# Altium schematic sheet PTPIC hat.SchDoc
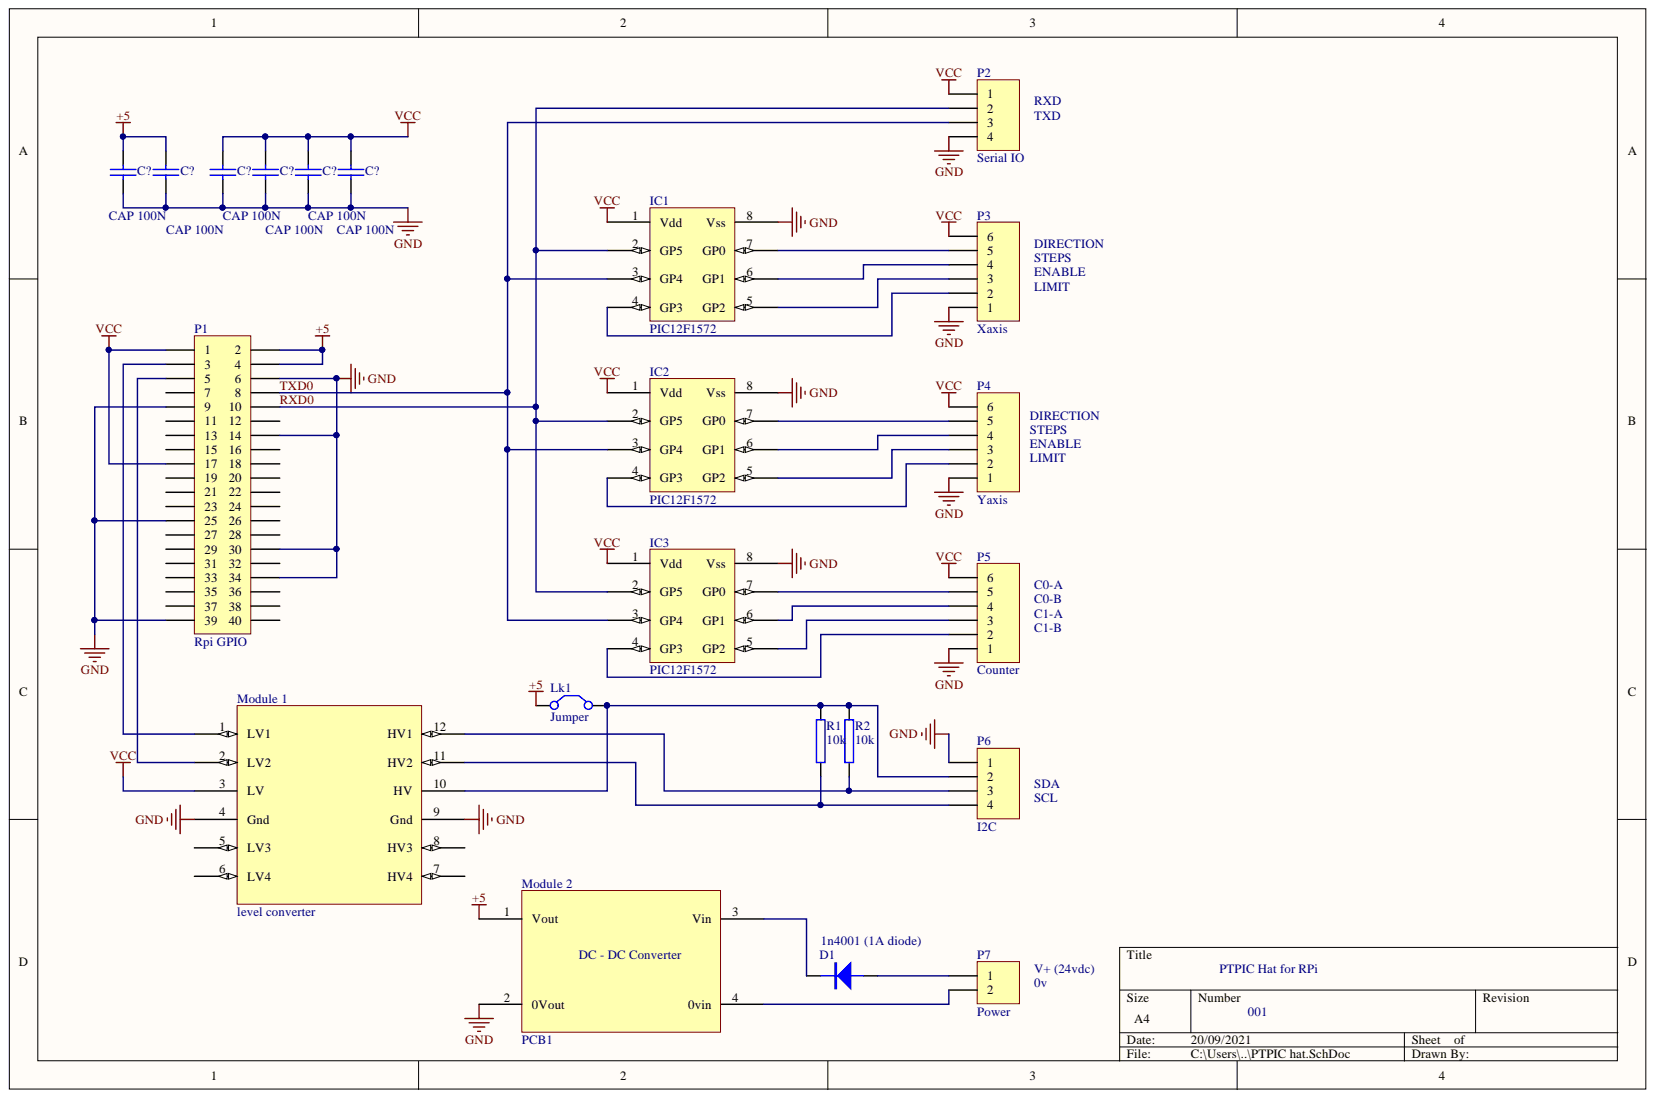
[diagram p0: PTPIC hat.SchDoc]

## Parts
C?  CAP 100N  [0805]
C?  CAP 100N  [0805]
C?  CAP 100N  [0805]
C?  CAP 100N  [0805]
C?  CAP 100N  [0805]
C?  CAP 100N  [0805]
D1  1n4001 (1A diode)  [DIODE0.4]
IC1  PIC12F1572  [DIP8]
IC2  PIC12F1572  [DIP8]
IC3  PIC12F1572  [DIP8]
Lk1  Jumper  [RAD-0.2]
Module_1  level converter
Module_2  PCB1
P1  Rpi GPIO  [HDR2X20]
P2  Serial IO  [HDR1X4]
P3  Xaxis  [HDR1X6]
P4  Yaxis  [HDR1X6]
P5  Counter  [HDR1X6]
P6  I2C  [HDR1X4]
P7  Power  [HDR1X2]
R1  10k  [AXIAL0.4]
R2  10k  [AXIAL0.4]

## Nets
+5: C?.1 Lk1.1 Module_2.1(Vout) P1.2 P1.4
GND: C?.2 IC1.8(Vss) IC2.8(Vss) IC3.8(Vss) Module_1.4(Gnd) Module_1.9(Gnd) Module_2.2(0Vout) P1.6 P1.9 P1.14 P1.25 P1.30 P1.34 P1.39 P2.4 P3.1 P4.1 P5.1 P6.1
VCC: C?.1 IC1.1(Vdd) IC2.1(Vdd) IC3.1(Vdd) Module_1.3(LV) P1.1 P1.17 P2.1 P3.6 P4.6 P5.6
RXD0: IC1.2(GP5) IC2.2(GP5) IC3.2(GP5) P1.10 P2.2
TXD0: IC1.3(GP4) IC2.3(GP4) IC3.3(GP4) P1.8 P2.3
NetD1_A: D1.A P7.1
NetD1_K: D1.K Module_2.3(Vin)
NetIC1_4: IC1.4(GP3) P3.2
NetIC1_5: IC1.5(GP2) P3.3
NetIC1_6: IC1.6(GP1) P3.4
NetIC1_7: IC1.7(GP0) P3.5
NetIC2_4: IC2.4(GP3) P4.2
NetIC2_5: IC2.5(GP2) P4.3
NetIC2_6: IC2.6(GP1) P4.4
NetIC2_7: IC2.7(GP0) P4.5
NetIC3_4: IC3.4(GP3) P5.2
NetIC3_5: IC3.5(GP2) P5.3
NetIC3_6: IC3.6(GP1) P5.4
NetIC3_7: IC3.7(GP0) P5.5
NetLk1_2: Lk1.2 Module_1.10(HV) P6.2 R1.2 R2.2
NetModule 1_1: Module_1.1(LV1) P1.3
NetModule 1_2: Module_1.2(LV2) P1.5
NetModule 1_11: Module_1.11(HV2) P6.4 R1.1
NetModule 1_12: Module_1.12(HV1) P6.3 R2.1
NetModule 2_4: Module_2.4(0vin) P7.2

Not connected: Module_1 5(LV3) 6(LV4) 7(HV4) 8(HV3); P1 7 11 12 13 15 16 18 19 20 21 22 23 24 26 27 28 29 31 32 33 35 36 37 38 40

## Library data of the parts
C? C? C? C? C? C? = CAP 100N: Cap smd; Component Kind: Standard; Library Name: Toddelec2.lib
D1 = 1N4148: 1N4001; Component Kind: Standard; Library Name: Toddelec2.lib
IC1-IC3 = PIC12F6XX: PIC 8 pin micro controlller; Component Kind: Standard; Library Name: Toddelec2.lib
Lk1 = Jumper: Jumper Wire; Component Kind: Standard; LatestRevisionDate: 17-Jul-2002; LatestRevisionNote: Re-released for DXP Platform.; Library Name: Miscellaneous Devices.IntLib; PackageDescription: Radial Cap, Thru-Hole; 2 Leads; 0.2 in Pin Spacing; PackageReference: RAD-0.2; Published: 24-Mar-1999; Publisher: Altium Limited
Module_2 = Component_1: Component Kind: Standard; Library Name: DC-DC.SchLib
P1 = Header 20X2: Header, 20-Pin, Dual row; Component Kind: Standard; LatestRevisionDate: 17-Jul-2002; LatestRevisionNote: Re-released for DXP Platform.; Library Name: Miscellaneous Connectors.IntLib; Signal Integrity: Connector; Publisher: Altium Limited
P2 P6 = Header 4: Header, 4-Pin; Component Kind: Standard; LatestRevisionDate: 17-Jul-2002; LatestRevisionNote: Re-released for DXP Platform.; Library Name: Miscellaneous Connectors.IntLib; Signal Integrity: Connector; Publisher: Altium Limited
P3-P5 = Header 6: Header, 6-Pin; Component Kind: Standard; LatestRevisionDate: 17-Jul-2002; LatestRevisionNote: Re-released for DXP Platform.; Library Name: Miscellaneous Connectors.IntLib; Signal Integrity: Connector; Publisher: Altium Limited
P7 = Header 2: Header, 2-Pin; Component Kind: Standard; LatestRevisionDate: 17-Jul-2002; LatestRevisionNote: Re-released for DXP Platform.; Library Name: Miscellaneous Connectors.IntLib; Signal Integrity: Connector; Publisher: Altium Limited
R1 R2 = RES 10K: resister 1/3w; Component Kind: Standard; Library Name: Toddelec2.lib
Module_1 = level converter: Component Kind: Standard; Library Name: DC-DC.SchLib
